# Altium schematic sheet VFD_CLOCK_BASE_BOARD.SchDoc
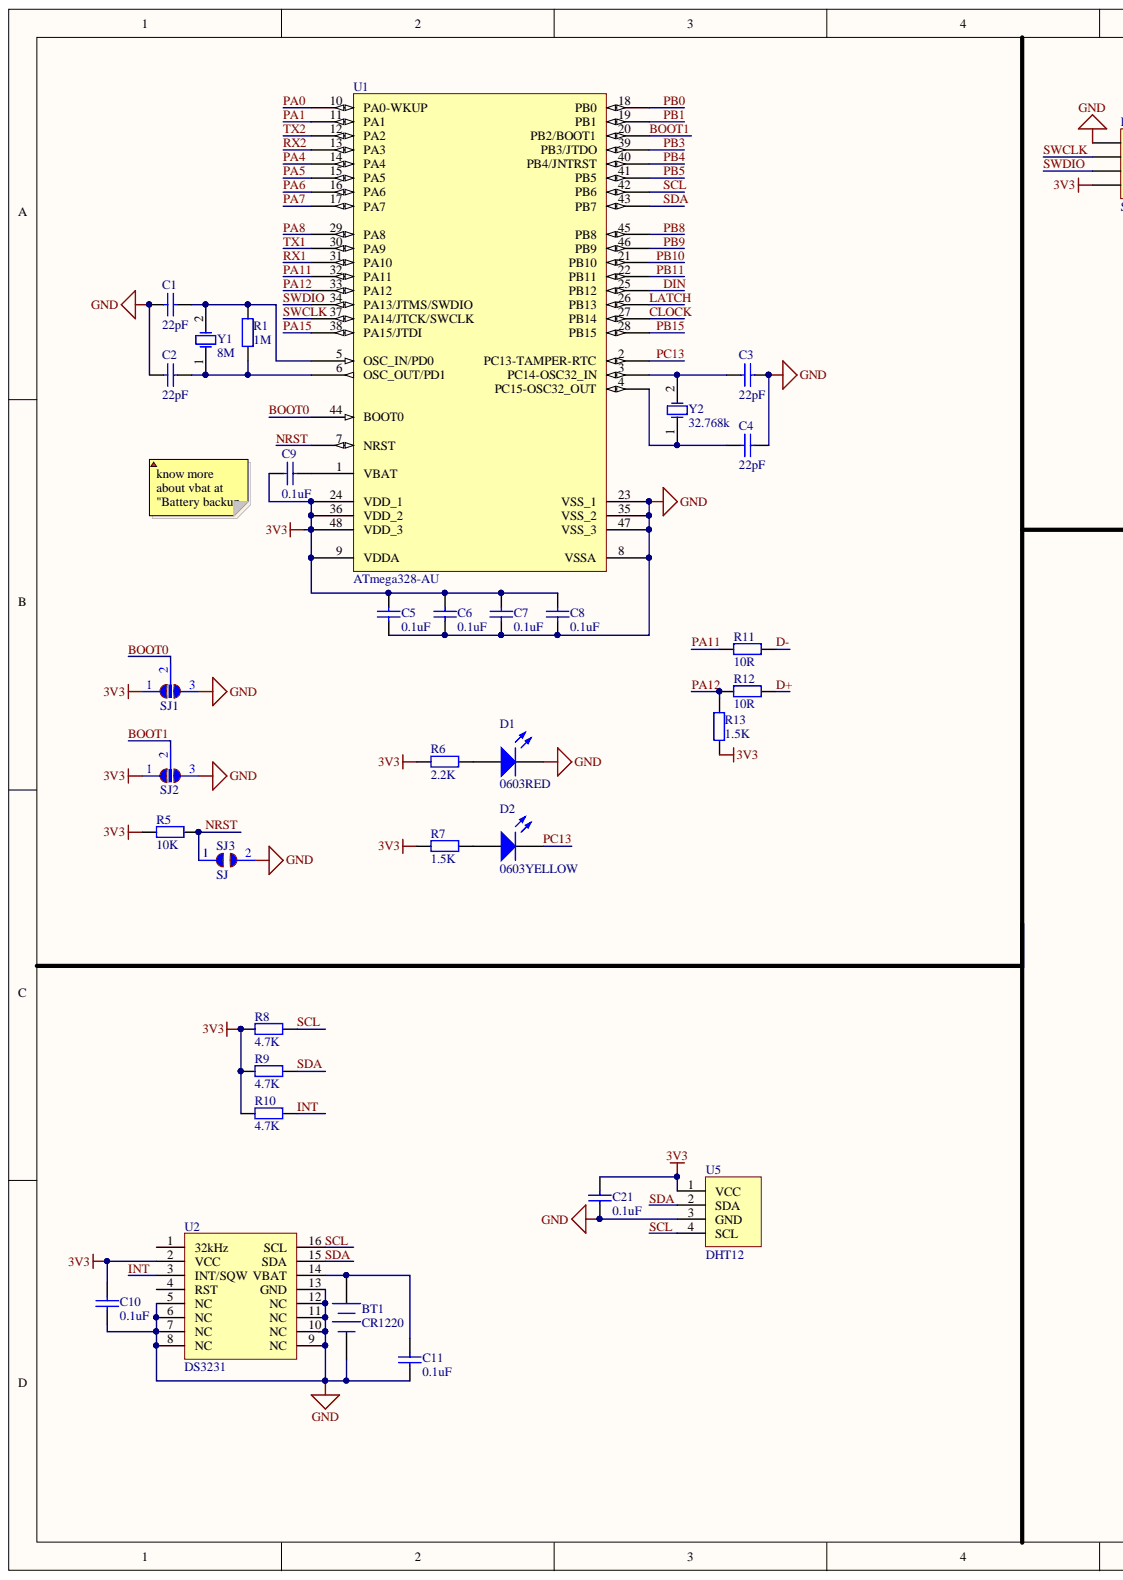
[diagram p0: VFD_CLOCK_BASE_BOARD.SchDoc, left]
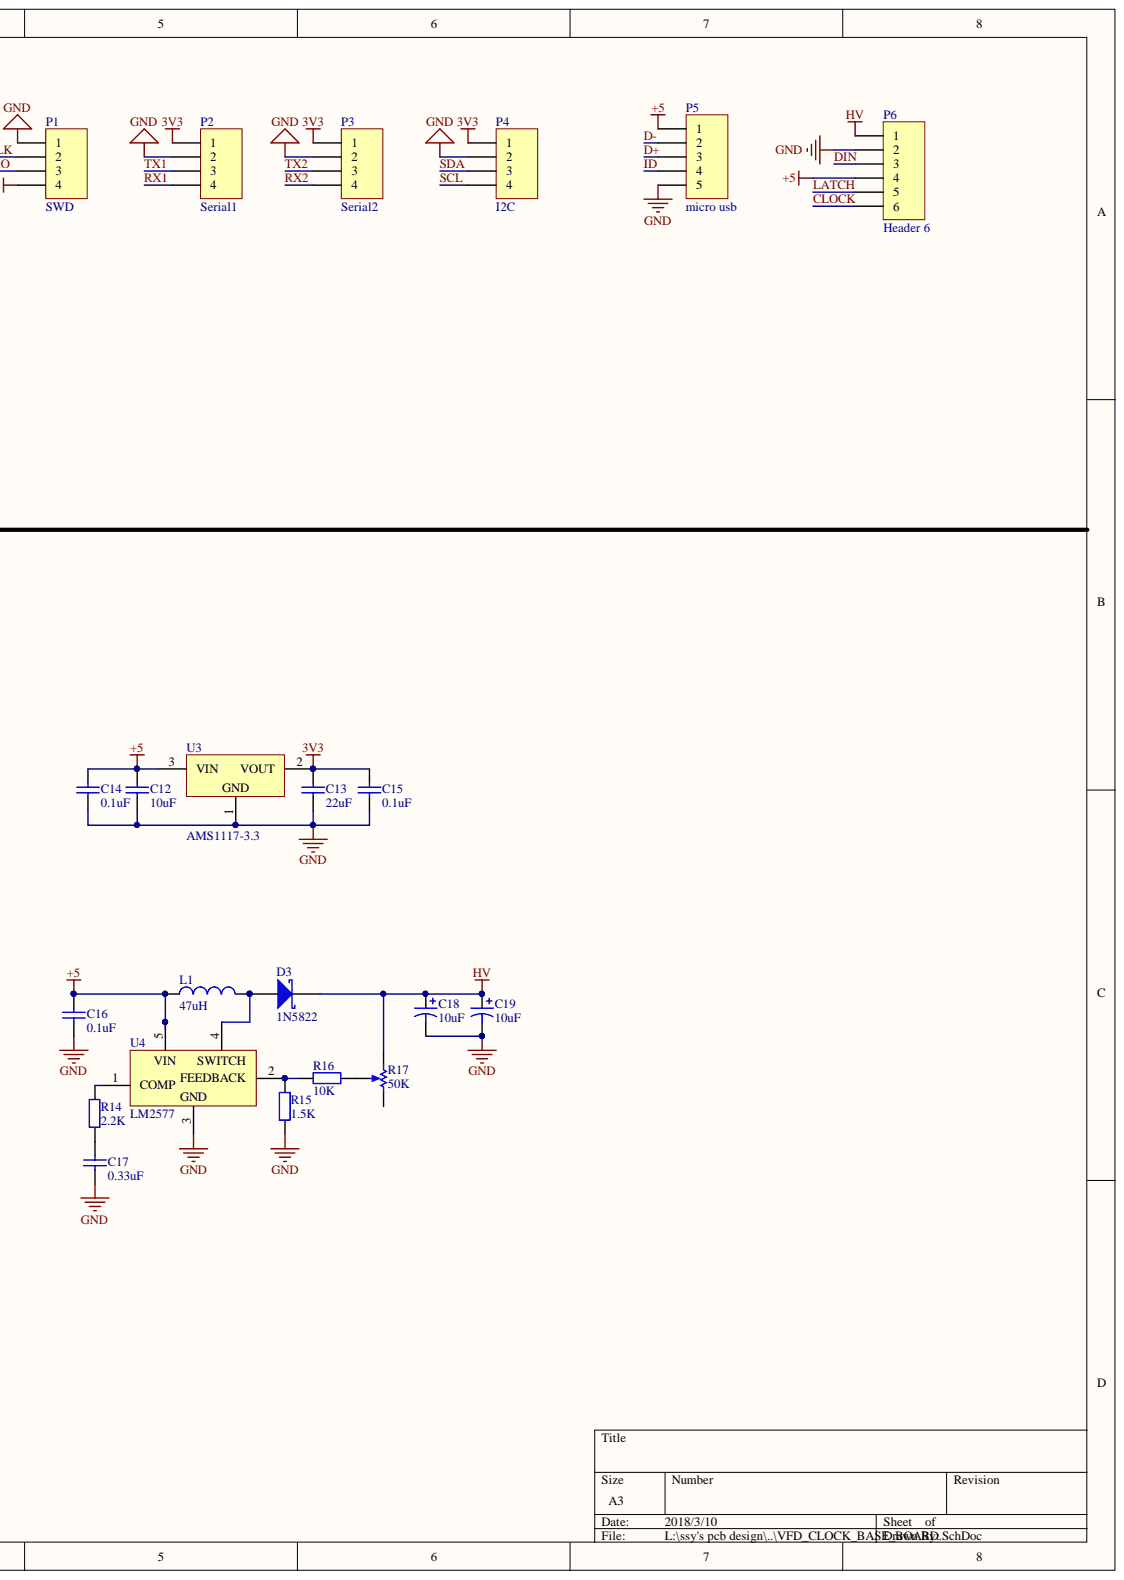
[diagram p1: VFD_CLOCK_BASE_BOARD.SchDoc, right]

## Parts
BT1  CR1220  [BAT_CR1220]
C1  22pF  [0603C_N]
C2  22pF  [0603C_N]
C3  22pF  [0603C_N]
C4  22pF  [0603C_N]
C5  0.1uF  [0603C_N]
C6  0.1uF  [0603C_N]
C7  0.1uF  [0603C_N]
C8  0.1uF  [0603C_N]
C9  0.1uF  [0603C_N]
C10  0.1uF  [0603C_N]
C11  0.1uF  [0603C_N]
C12  10uF  [0603C_N]
C13  22uF  [0603C_N]
C14  0.1uF  [0603C_N]
C15  0.1uF  [0603C_N]
C16  0.1uF  [0603C_N]
C17  0.33uF  [0603C_N]
C18  10uF  [CAP_SMD_SIZE_D_F6.3]
C19  10uF  [CAP_SMD_SIZE_D_F6.3]
C21  0.1uF  [0603C_N]
D1  0603RED  [0603LED_N]
D2  0603YELLOW  [0603LED_N]
D3  1N5822  [SMA]
L1  47uH  [CD54]
P1  SWD  [CONNECTER_2.0_1X4]
P2  Serial1  [SH1.25_4P_SMT]
P3  Serial2  [SH1.25_4P_SMT]
P4  I2C  [SH1.25_4P_SMT]
P5  micro usb  [USB_MICRO]
P6  Header 6  [CONNECTER_2.54_1X6]
R1  1M  [0603R_N]
R5  10K  [0603R_N]
R6  2.2K  [0603R_N]
R7  1.5K  [0603R_N]
R8  4.7K  [0603R_N]
R9  4.7K  [0603R_N]
R10  4.7K  [0603R_N]
R11  10R  [0603R_N]
R12  10R  [0603R_N]
R13  1.5K  [0603R_N]
R14  2.2K  [0603R_N]
R15  1.5K  [0603R_N]
R16  10K  [0603R_N]
R17  50K  [POT_3*3]
SJ1  SJ_2  [SOLDER_JUMPER_2WAY]  (value not drawn)
SJ2  SJ_2  [SOLDER_JUMPER_2WAY]
SJ3  SJ  [SOLDER_JUMPER]
U1  ATmega328-AU  [TQFP48_N]
U2  DS3231  [SOIC127P-16N]
U3  AMS1117-3.3  [SOT223-4N]
U4  LM2577  [TO263-5]
U5  DHT12  [DHT12]
Y1  8M  [XTAL_HC-49SMD]
Y2  32.768k  [XTAL-TC26]

## Nets
3V3: C5.2 C6.2 C7.2 C8.2 C9.1 C10.2 C13.2 C15.2 C21.2 P1.4 P2.1 P3.1 P4.1 R5.2 R6.1 R7.1 R8.2 R9.2 R10.2 R13.2 SJ1.1 SJ2.1 U1.9(VDDA) U1.24(VDD_1) U1.36(VDD_2) U1.48(VDD_3) U2.2(VCC) U3.2 U5.1(VCC)
+5: C12.2 C14.2 C16.2 L1.1 P5.1 P6.4 U3.3 U4.5
GND: BT1.2 C1.1 C2.1 C3.1 C4.1 C5.1 C6.1 C7.1 C8.1 C10.1 C11.1 C12.1 C13.1 C14.1 C15.1 C16.1 C17.1 C18.2 C19.2 C21.1 D1.2 P1.1 P2.2 P3.2 P4.2 P5.5 P6.2 R15.2 SJ1.3 SJ2.3 SJ3.2 U1.8(VSSA) U1.23(VSS_1) U1.35(VSS_2) U1.47(VSS_3) U2.5(NC) U2.6(NC) U2.7(NC) U2.8(NC) U2.9(NC) U2.10(NC) U2.11(NC) U2.12(NC) U2.13(GND) U3.1 U4.3 U5.3(GND)
HV: C18.1 C19.1 D3.2 P6.1 R17.3
BOOT0: SJ1.2 U1.44(BOOT0)
BOOT1: SJ2.2 U1.20(PB2/BOOT1)
CLOCK: P6.6 U1.27(PB14)
D+: P5.3 R12.1
D-: P5.2 R11.1
DIN: P6.3 U1.25(PB12)
ID: P5.4
INT: R10.1 U2.3(INT/SQW)
LATCH: P6.5 U1.26(PB13)
NRST: R5.1 SJ3.1 U1.7(NRST)
PA0: U1.10(PA0-WKUP)
PA1: U1.11(PA1)
PA4: U1.14(PA4)
PA5: U1.15(PA5)
PA6: U1.16(PA6)
PA7: U1.17(PA7)
PA8: U1.29(PA8)
PA11: R11.2 U1.32(PA11)
PA12: R12.2 R13.1 U1.33(PA12)
PA15: U1.38(PA15/JTDI)
PB0: U1.18(PB0)
PB1: U1.19(PB1)
PB3: U1.39(PB3/JTDO)
PB4: U1.40(PB4/JNTRST)
PB5: U1.41(PB5)
PB8: U1.45(PB8)
PB9: U1.46(PB9)
PB10: U1.21(PB10)
PB11: U1.22(PB11)
PB15: U1.28(PB15)
PC13: D2.2 U1.2(PC13-TAMPER-RTC)
RX1: P2.4 U1.31(PA10)
RX2: P3.4 U1.13(PA3)
SCL: P4.4 R8.1 U1.42(PB6) U2.16(SCL) U5.4(SCL)
SDA: P4.3 R9.1 U1.43(PB7) U2.15(SDA) U5.2(SDA)
SWCLK: P1.2 U1.37(PA14/JTCK/SWCLK)
SWDIO: P1.3 U1.34(PA13/JTMS/SWDIO)
TX1: P2.3 U1.30(PA9)
TX2: P3.3 U1.12(PA2)
NetBT1_1: BT1.1 C11.2 U2.14(VBAT)
NetC1_2: C1.2 R1.2 U1.5(OSC_IN/PD0) Y1.2
NetC2_2: C2.2 R1.1 U1.6(OSC_OUT/PD1) Y1.1
NetC3_2: C3.2 U1.3(PC14-OSC32_IN) Y2.2
NetC4_2: C4.2 U1.4(PC15-OSC32_OUT) Y2.1
NetC9_2: C9.2 U1.1(VBAT)
NetC17_2: C17.2 R14.2
NetD1_1: D1.1 R6.2
NetD2_1: D2.1 R7.2
NetD3_1: D3.1 L1.2 U4.4
NetR14_1: R14.1 U4.1(COMP)
NetR15_1: R15.1 R16.1 U4.2(FEEDBACK)
NetR16_2: R16.2 R17.2

Not connected: R17 1; U2 1(32kHz) 4(RST)

## Library data of the parts
BT1 = Battery: Multicell Battery; Component Kind: Standard; LatestRevisionDate: 17-Jul-2002; LatestRevisionNote: Re-released for DXP Platform.; Library Name: Miscellaneous Devices.IntLib; PackageDescription: Battery; 2 Leads; PackageReference: BAT-2; Published: 24-Mar-1999; Publisher: Altium Limited
C1-C17 C21 = Cap: Capacitor; Value: 100pF; Component Kind: Standard; LatestRevisionDate: 17-Jul-2002; LatestRevisionNote: Re-released for DXP Platform.; Library Name: Miscellaneous Devices.IntLib; PackageDescription: Radial Cap, Thru-Hole; 2 Leads; 0.3 in Pin Spacing; PackageReference: RAD-0.3; Published: 8-Jun-2000; Signal Integrity: Cap; Simulation: CAP; Publisher: Altium Limited
C18 C19 = Cap Pol1: Polarized Capacitor (Radial); Value: 100pF; Component Kind: Standard; LatestRevisionDate: 17-Jul-2002; LatestRevisionNote: Re-released for DXP Platform.; Library Name: Miscellaneous Devices.IntLib; PackageDescription: Polarized Capacitor (Radial); 2 Leads; PackageReference: RB7.6-15; Published: 8-Jun-2000; Signal Integrity: Cap Pol; Simulation: CAP; Publisher: Altium Limited
D1 D2 = LED0: Typical INFRARED GaAs LED; Component Kind: Standard; LatestRevisionDate: 08-Jul-2005; LatestRevisionNote: Mech Layer 1 removed.; Library Name: Miscellaneous Devices.IntLib; PackageDescription: LED; 2 Leads; PackageReference: LED-0; Published: 8-Jun-2000; Simulation: LED0; Publisher: Altium Limited
D3 = Diode 11DQ03: Schottky Rectifier; Code_JEDEC: DO-204-AL; Component Kind: Standard; ComponentLink1Description: Manufacturer Link; ComponentLink1URL: http://www.irf.com/; ComponentLink2Description: Datasheet; ComponentLink2URL: http://www.irf.com/product-info/datasheets/data/11dq03.pdf; DatasheetVersion: Dec-1997; LatestRevisionDate: 17-Jul-2002; LatestRevisionNote: Re-released for DXP Platform.; Library Name: Miscellaneous Devices.IntLib; PackageDescription: Diode, Axial; Body 5.2 x 2.7 mm (LxDia. max), Lead Dia. 0.86 mm (max); PackageReference: DO-204AL; Published: 8-Jun-2000; Simulation: 11DQ03; Publisher: Altium Limited
L1 = Inductor: Code_IEC: 1005; Value: 10mH; Code_JEITA: 0402; Component Kind: Standard; Description: Inductor; LatestRevisionDate: 29-May-2009; LatestRevisionNote: IPC-7351 Footprint Added.; Library Name: Miscellaneous Devices.IntLib; PackageDescription: Chip Inductor; PackageReference: 0402-A; Published: 8-Jun-2000; Signal Integrity: Inductor; Simulation: INDUCTOR; Publisher: Altium Limited
P1-P4 = Header 4: Header, 4-Pin; Component Kind: Standard; LatestRevisionDate: 17-Jul-2002; LatestRevisionNote: Re-released for DXP Platform.; Library Name: Miscellaneous Connectors.IntLib; Signal Integrity: Connector; Publisher: Altium Limited
P5 = Header 5: Header, 5-Pin; Component Kind: Standard; LatestRevisionDate: 17-Jul-2002; LatestRevisionNote: Re-released for DXP Platform.; Library Name: Miscellaneous Connectors.IntLib; Signal Integrity: Connector; Publisher: Altium Limited
P6 = Header 6: Header, 6-Pin; Component Kind: Standard; LatestRevisionDate: 17-Jul-2002; LatestRevisionNote: Re-released for DXP Platform.; Library Name: Miscellaneous Connectors.IntLib; Signal Integrity: Connector; Publisher: Altium Limited
R1 R5-R16 = Res2: Resistor; Value: 1K; Component Kind: Standard; LatestRevisionDate: 17-Jul-2002; LatestRevisionNote: Re-released for DXP Platform.; Library Name: Miscellaneous Devices.IntLib; PackageDescription: Axial Device, Thru-Hole; 2 Leads; 0.4 in Pin Spacing; PackageReference: AXIAL-0.4; Published: 8-Jun-2000; Signal Integrity: Res; Simulation: RESISTOR; Publisher: Altium Limited
R17 = Pot: Potentiometer; Set Position: 0.5; Sim Note: Enter the Resistance value and the set point into parameter fields.; Value: 1K; Component Kind: Standard; LatestRevisionDate: 17-Jul-2002; LatestRevisionNote: Re-released for DXP Platform.; PackageDescription: Variable Resistor, Thru-Hole; 3 Leads; PackageReference: VR5; Published: 8-Jun-2000; Library Name: ssy library.SchLib; Publisher: Altium Limited
SJ1 SJ2 = SOLDER_JUMPER_2WAY: 2-Way Solder Jumper; Component Kind: Standard; Library Name: ssy library.SchLib
SJ3 = SOLDER_JUMPER: Solder Jumper; Component Kind: Standard; Library Name: ssy library.SchLib
U1 = STM32F103C8T6: STM32 ARM-based 32-bit MCU with 64 Kbytes Flash, 48-pin LQFP, Industrial Temperature; Component Kind: Standard; ComponentLink1Description: Manufacturer Link; ComponentLink1URL: www.st.com/stm32; ComponentLink2Description: Datasheet; ComponentLink2URL: http://www.st.com/stonline/products/literature/ds/13587.pdf; DatasheetVersion: rev6, Mar-2008; PackageDescription: 48-Pin Low-Profile Quad Flat Pack, 7 x 7 mm Body, 0.5 mm Pitch (LQFP48); PackageReference: LQFP48; PackageVersion: Mar-2008; Published: 30-May-2008; Library Name: ssy library.SchLib; Publisher: Altium Limited
U3 = AMS1117: Component Kind: Standard; Description: AMS1117; Library Name: ssy library.SchLib
Y1 Y2 = XTAL: Crystal Oscillator; Component Kind: Standard; LatestRevisionDate: 17-Jul-2002; LatestRevisionNote: Re-released for DXP Platform.; Library Name: Miscellaneous Devices.IntLib; PackageDescription: Crystal, Thru-Hole; 2 Leads; Body 3.1 x 8.2 mm (Dia.xH); PackageReference: R38; Published: 8-Jun-2000; Simulation: XTAL; Publisher: Altium Limited
U2 = DS3231: Component Kind: Standard; Description: DS3231; Library Name: ssy library.SchLib
U4 = LM2577: Component Kind: Standard; Description: LM2577; Library Name: ssy library.SchLib
U5 = DHT12: Component Kind: Standard; Library Name: ssy library.SchLib
